# Altium schematic sheet INTERFACE.SchDoc
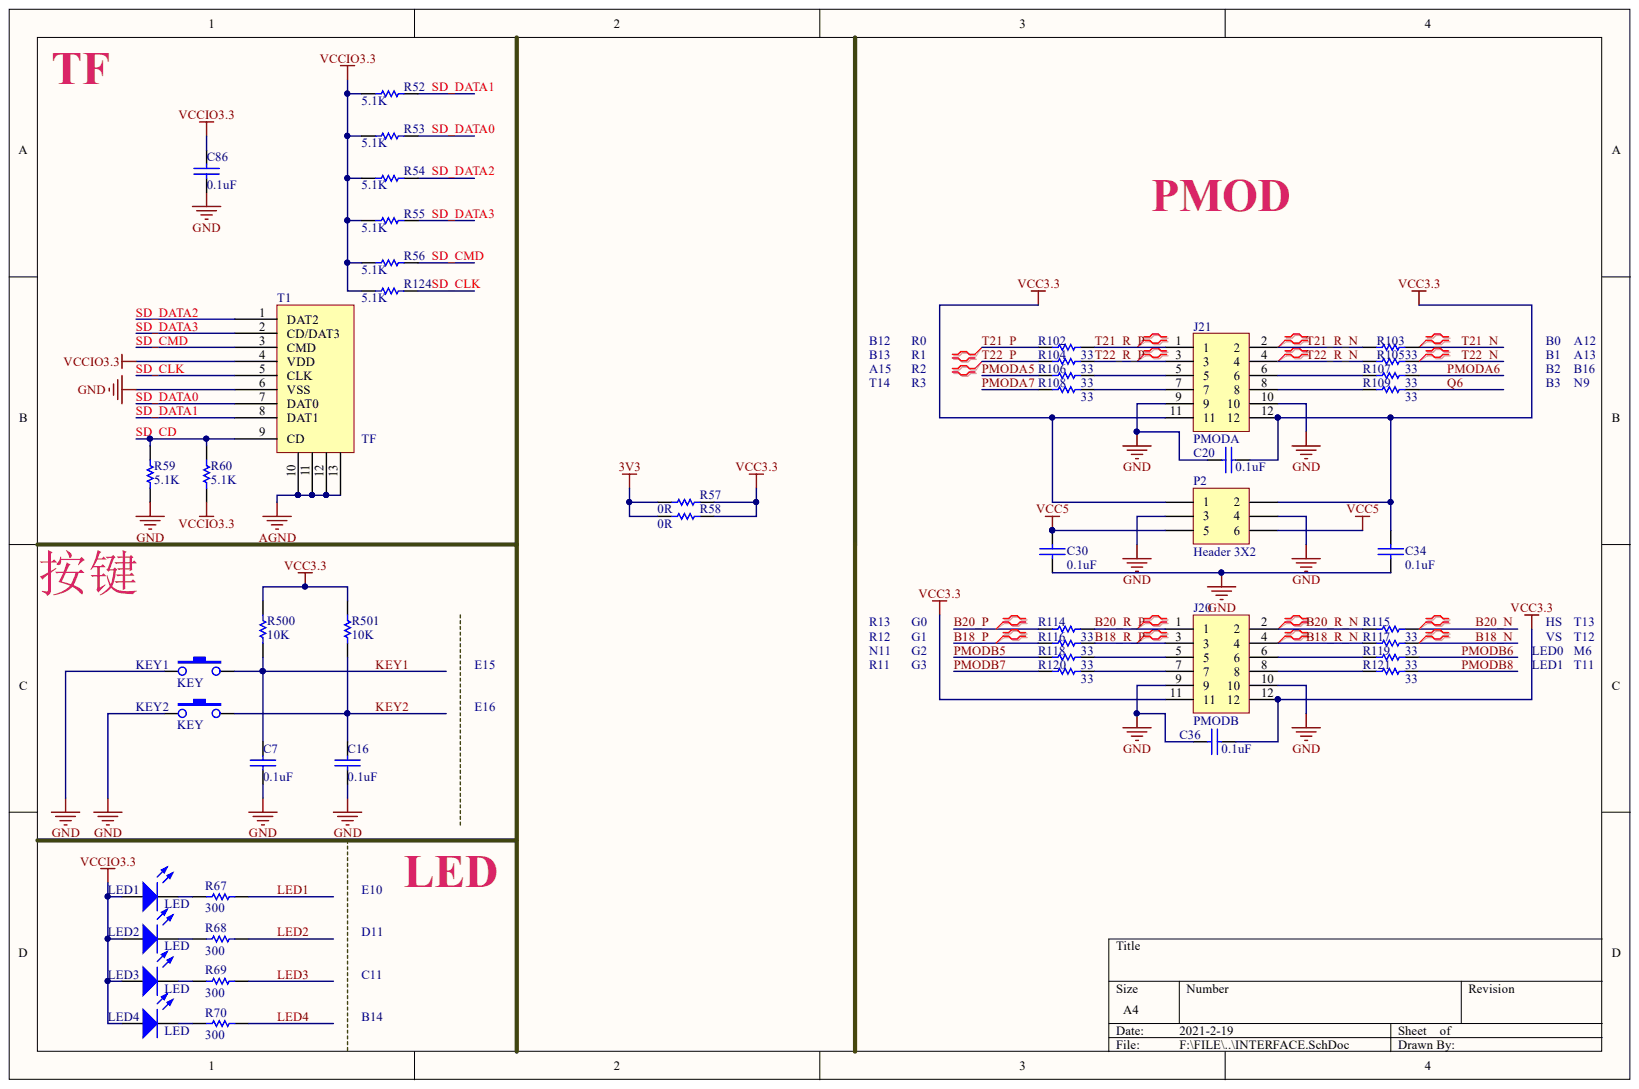
[diagram p0: INTERFACE.SchDoc]

## Parts
C7  0.1uF  [C0402]
C16  0.1uF  [C0402]
C20  0.1uF  [C0402]
C30  0.1uF  [C0402]
C34  0.1uF  [C0402]
C36  0.1uF  [C0402]
C86  0.1uF  [C0402]
J20  PMODB  [2X6P RIGHT]
J21  PMODA  [2X6P RIGHT]
KEY1  KEY  [SMD-3X6X2.5]
KEY2  KEY  [SMD-3X6X2.5]
LED1  LED  [LED0603-R-RD]
LED2  LED  [LED0603-R-RD]
LED3  LED  [LED0603-R-RD]
LED4  LED  [LED0603-R-RD]
P2  Header 3X2  [HDR2X3]
R52  5.1K  [R0402]
R53  5.1K  [R0402]
R54  5.1K  [R0402]
R55  5.1K  [R0402]
R56  5.1K  [R0402]
R57  0R  [0603_Q0603A]
R58  0R  [0603_Q0603A]
R59  5.1K  [R0402]
R60  5.1K  [R0402]
R67  300  [R0402]
R68  300  [R0402]
R69  300  [R0402]
R70  300  [R0402]
R102  33  [R_0402]
R103  33  [R_0402]
R104  33  [R_0402]
R105  33  [R_0402]
R106  33  [R_0402]
R107  33  [R_0402]
R108  33  [R_0402]
R109  33  [R_0402]
R114  33  [R_0402]
R115  33  [R_0402]
R116  33  [R_0402]
R117  33  [R_0402]
R118  33  [R_0402]
R119  33  [R_0402]
R120  33  [R_0402]
R121  33  [R_0402]
R124  5.1K  [R0402]
R500  10K  [R0402]
R501  10K  [R0402]
T1  TF  [TF1]

## Nets
3V3: R57.2 R58.2
AGND: T1.10(CD) T1.11 T1.12 T1.13
GND: C7.2 C16.2 C20.1 C30.1 C34.1 C36.1 C86.2 J20.9 J20.10 J21.9 J21.10 KEY1.1 KEY2.1 P2.3 P2.4 R59.1 T1.6(VSS)
VCC3.3: C20.2 C34.2 C36.2 J20.11 J20.12 J21.11 J21.12 P2.1 P2.2 R57.1 R58.1 R500.1 R501.1
VCC5: C30.2 P2.5 P2.6
VCCIO3.3: C86.1 LED1.1 LED2.1 LED3.1 LED4.1 R52.1 R53.1 R54.1 R55.1 R56.1 R60.1 R124.1 T1.4(VDD)
B18_N: R117.2
B18_P: R116.1
B18_R_N: J20.4 R117.1
B18_R_P: J20.3 R116.2
B20_N: R115.2
B20_P: R114.1
B20_R_N: J20.2 R115.1
B20_R_P: J20.1 R114.2
KEY1: C7.1 KEY1.3 R500.2
KEY2: C16.1 KEY2.3 R501.2
LED1: R67.2
LED2: R68.2
LED3: R69.2
LED4: R70.2
PMODA5: R106.1
PMODA6: R107.2
PMODA7: R108.1
PMODB5: R118.1
PMODB6: R119.2
PMODB7: R120.1
PMODB8: R121.2
Q6: R109.2
SD_CD: R59.2 R60.2 T1.9
SD_CLK: R124.2 T1.5(CLK)
SD_CMD: R56.2 T1.3(CMD)
SD_DATA0: R53.2 T1.7(DAT0)
SD_DATA1: R52.2 T1.8(DAT1)
SD_DATA2: R54.2 T1.1(DAT2)
SD_DATA3: R55.2 T1.2(CD/DAT3)
T21_N: R103.2
T21_P: R102.1
T21_R_N: J21.2 R103.1
T21_R_P: J21.1 R102.2
T22_N: R105.2
T22_P: R104.1
T22_R_N: J21.4 R105.1
T22_R_P: J21.3 R104.2
NetJ20_5: J20.5 R118.2
NetJ20_6: J20.6 R119.1
NetJ20_7: J20.7 R120.2
NetJ20_8: J20.8 R121.1
NetJ21_5: J21.5 R106.2
NetJ21_6: J21.6 R107.1
NetJ21_7: J21.7 R108.2
NetJ21_8: J21.8 R109.1
NetLED1_2: LED1.2 R67.1
NetLED2_2: LED2.2 R68.1
NetLED3_2: LED3.2 R69.1
NetLED4_2: LED4.2 R70.1
N00289:

## Library data of the parts
C7 C16 C20 C30 C34 C36 C86 = CAP: 无极性电容; Component Kind: Standard; Library Name: 电容.IntLib
J20 J21 = Female HDR2X6: Component Kind: Standard; Library Name: 排母.IntLib
P2 = Header 3X2: Header, 3-Pin, Dual row; Component Kind: Standard; LatestRevisionDate: 17-Jul-2002; LatestRevisionNote: Re-released for DXP Platform.; Library Name: Miscellaneous Connectors.IntLib; Signal Integrity: Connector; Publisher: Altium Limited
R52-R60 R67-R70 R102-R109 R114-R121 R124 R500 R501 = RES: Component Kind: Standard
  R52-R60 R124 R500 R501: Library Name: 电阻.IntLib
  R67-R70 R102-R109 R114-R121: Library Name: the_god_of_death.IntLib
KEY1 KEY2 = KEY: Component Kind: Standard; Library Name: 轻触开关.IntLib
LED1-LED4 = LED: Component Kind: Standard; Library Name: the_god_of_death.IntLib
T1 = TF: Component Kind: Standard; Library Name: the_god_of_death.SchLib
